# Altium schematic sheet 1.1.3_Interface_board_JTAG.SchDoc
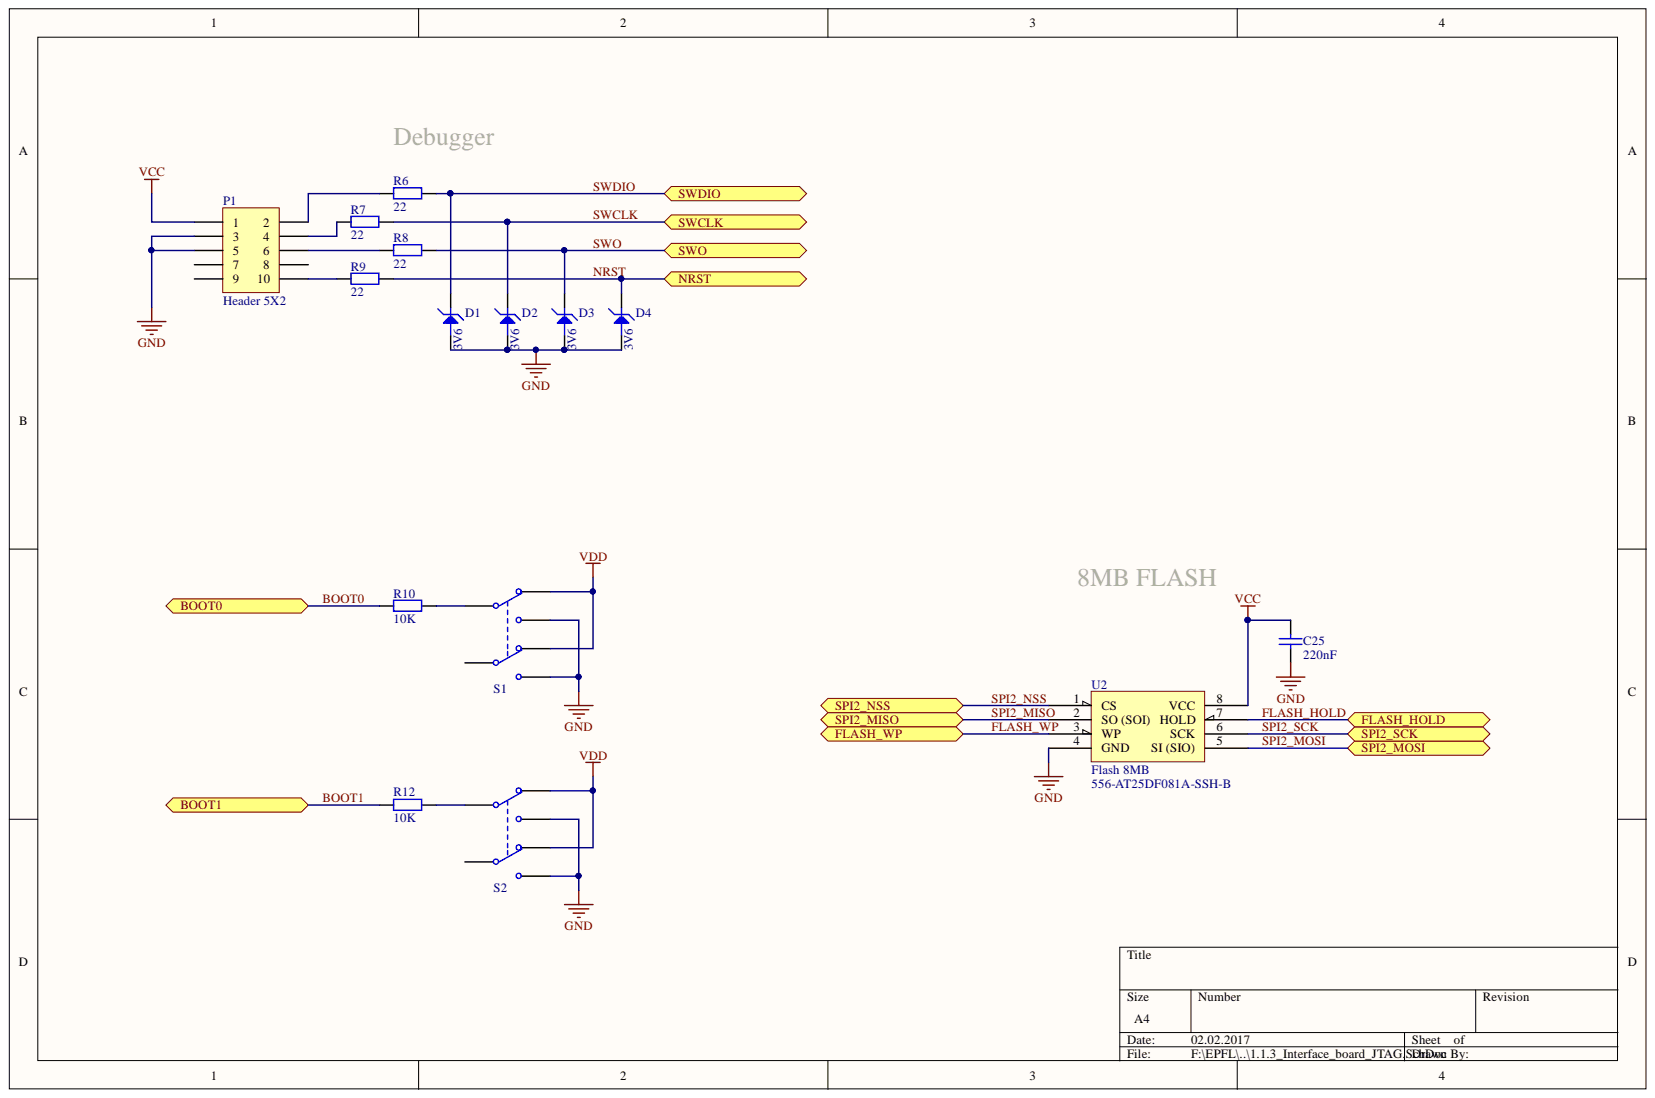
[diagram p0: 1.1.3_Interface_board_JTAG.SchDoc]

## Parts
C25  220nF  [CAP0603R]
D1  3V6  [SOT23]
D2  3V6  [SOT23]
D3  3V6  [SOT23]
D4  3V6  [SOT23]
P1  Header 5X2  [HDR2X5]
R6  22  [0603]
R7  22  [0603]
R8  22  [0603]
R9  22  [0603]
R10  10K  [0603]
R12  10K  [0603]
S1  SW DPDT  [SMD Slide Switches ON-ON]  (value not drawn)
S2  SW DPDT  [SMD Slide Switches ON-ON]  (value not drawn)
U2  Flash 8MB  Supplier Part Number 1: 556-AT25DF081A-SSH-B  [SOIC-8]

## Ports
BOOT0, BOOT1, FLASH_HOLD, FLASH_WP, NRST, SPI2_MISO, SPI2_MOSI, SPI2_NSS, SPI2_SCK, SWCLK, SWDIO, SWO

## Nets
GND: C25.1 D1.1 D2.1 D3.1 D4.1 P1.3 P1.5 S1.1 S1.4 S2.1 S2.4 U2.4(GND)
VCC: C25.2 P1.1 U2.8(VCC)
VDD: S1.3 S1.6 S2.3 S2.6
BOOT0: R10.1 ; port BOOT0
BOOT1: R12.1 ; port BOOT1
FLASH_HOLD: U2.7(HOLD) ; port FLASH_HOLD
FLASH_WP: U2.3(WP) ; port FLASH_WP
NRST: D4.3 R9.2 ; port NRST
SPI2_MISO: U2.2(SO_(SOI)) ; port SPI2_MISO
SPI2_MOSI: U2.5(SI_(SIO)) ; port SPI2_MOSI
SPI2_NSS: U2.1(CS) ; port SPI2_NSS
SPI2_SCK: U2.6(SCK) ; port SPI2_SCK
SWCLK: D2.3 R7.2 ; port SWCLK
SWDIO: D1.3 R6.2 ; port SWDIO
SWO: D3.3 R8.2 ; port SWO
NetP1_2: P1.2 R6.1
NetP1_4: P1.4 R7.1
NetP1_6: P1.6 R8.1
NetP1_10: P1.10 R9.1
NetR10_2: R10.2 S1.2
NetR12_2: R12.2 S2.2

Not connected: P1 7 8 9; S1 5; S2 5

## Library data of the parts
C25 = C_220nF: Capacitor; Component Kind: Standard; Signal Integrity: Cap; Simulation: CAP; Supplier 1: Mouser; Supplier Part Number 1: 81-GCG188R91H224KA1D; Library Name: Rocket_library.SchLib
D1-D4 = DZ_3V6_MMBZ522: Zener Diodes 3.6V 225mW; Component Kind: Standard; Supplier 1: Mouser; Supplier Part Number 1: 863-MMBZ5227BLT1G; Library Name: Rocket_library.SchLib
P1 = Header 5X2: Header, 5-Pin, Dual row; Component Kind: Standard; LatestRevisionDate: 17-Jul-2002; LatestRevisionNote: Re-released for DXP Platform.; Library Name: Miscellaneous Connectors.IntLib; Signal Integrity: Connector; Publisher: Altium Limited
R6-R9 = R_0603_025W_22Ohm: 0.25W 22Ohm; Component Kind: Standard; LatestRevisionDate: 17-Jul-2002; LatestRevisionNote: Re-released for DXP Platform.; PackageDescription: Axial Device, Thru-Hole; 2 Leads; 0.4 in Pin Spacing; PackageReference: AXIAL-0.4; Signal Integrity: Res; Simulation: RESISTOR; Supplier 1: Mouser; Supplier Part Number 1: 667-ERJ-PA3J220V; Library Name: Rocket_library.SchLib
R10 R12 = R_0603_01W_10K: 0.1W 10K; Component Kind: Standard; Signal Integrity: Res; Simulation: RESISTOR; Supplier 1: Mouser; Supplier Part Number 1: 667-ERJ-3GEYJ103V; Library Name: Rocket_library.SchLib
S1 S2 = SW DPDT: Switch; Component Kind: Standard; Supplier: Mouser; Supplier Part Number: 688-SSSS820101; Library Name: Rocket_library.SchLib
U2 = Flash 8MB SPI: Component Kind: Standard; Description: Flash 8MB; Supplier 1: Mouser; Library Name: Rocket_library.SchLib
